# Altium schematic sheet V831.SchDoc
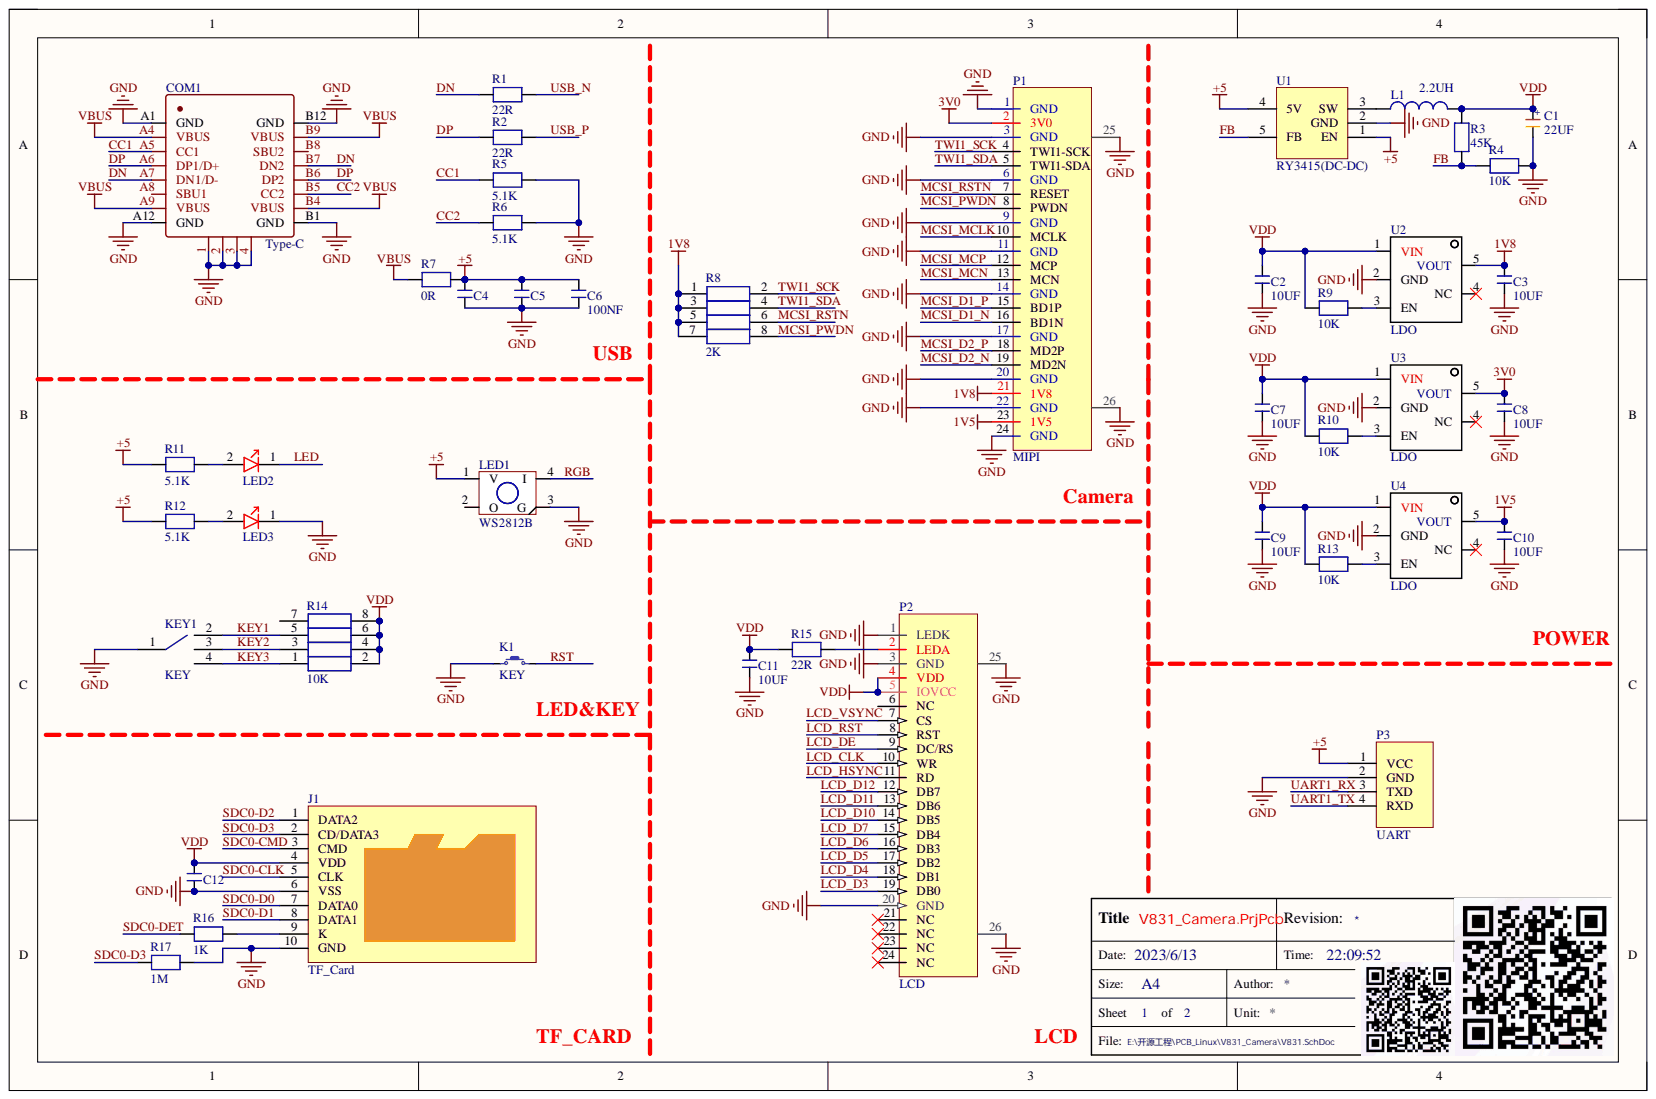
[diagram p0: V831.SchDoc]

## Parts
C1  22UF  [CAP_3216_A]
C2  10UF  [CAP_1206]
C3  10UF  [CAP_0603]
C4  100NF  [CAP_0603]  (value not drawn)
C5  100NF  [CAP_0603]  (value not drawn)
C6  100NF  [CAP_0603]
C7  10UF  [CAP_0603]
C8  10UF  [CAP_0603]
C9  10UF  [CAP_0603]
C10  10UF  [CAP_0603]
C11  10UF  [CAP_0603]
C12  100NF  [CAP_0603]  (value not drawn)
COM1  Type-C  [USB-Type-C_16A]
J1  TF_Card  [TF_Card]
K1  KEY  [KEY_SMD-4P]
KEY1  KEY  [KEY-K1-1502SA-LY-K3]
L1  2.2UH  [Inductance_nr]
LED1  WS2812B  [WS2812B]
LED2  LED_R/G/B/Y  [LED_0603_B]  (value not drawn)
LED3  LED_R/G/B/Y  [LED_0603_R]  (value not drawn)
P1  MIPI  [FPC-24Pin]
P2  LCD  [FPC-24Pin]
P3  UART  [XH2.54-WS-4P]
R1  22R  [RESC_0603_2]
R2  22R  [RESC_0603_2]
R3  45K  [RESC_0603_2]
R4  10K  [RESC_0603_2]
R5  5.1K  [RESC_0603_2]
R6  5.1K  [RESC_0603_2]
R7  0R  [RESC_0603_2]
R8  2K  [RESC_0603_4]
R9  10K  [RESC_0603_2]
R10  10K  [RESC_0603_2]
R11  5.1K  [RESC_0603_2]
R12  5.1K  [RESC_0603_2]
R13  10K  [RESC_0603_2]
R14  10K  [RESC_0603_4]
R15  22R  [RESC_0603_2]
R16  1K  [RESC_0603_2]
R17  1M  [RESC_0603_2]
U1  RY3415(DC-DC)  [SOT23_5]
U2  LDO  [SOT23_5]
U3  LDO  [SOT23_5]
U4  LDO  [SOT23_5]

## Nets
1V5: C10.2 P1.23(1V5) U4.5(VOUT)
1V8: C3.2 P1.21(1V8) R8.1 R8.3 R8.5 R8.7 U2.5(VOUT)
3V0: C8.2 P1.2(3V0) U3.5(VOUT)
+5: C4.2 C5.2 C6.2 LED1.1(V) P3.1(VCC) R7.2 R11.1 R12.1 U1.1(EN) U1.4(5V)
GND: C1.2 C2.1 C3.1 C4.1 C5.1 C6.1 C7.1 C8.1 C9.1 C10.1 C11.1 C12.1 COM1.1 COM1.2 COM1.3 COM1.4 COM1.A1(GND) COM1.A12(GND) COM1.B1(GND) COM1.B12(GND) J1.6(VSS) J1.10(GND) K1.1 KEY1.1 LED1.3(G) LED3.1 P1.1(GND) P1.3(GND) P1.6(GND) P1.9(GND) P1.11(GND) P1.14(GND) P1.17(GND) P1.20(GND) P1.22(GND) P1.24(GND) P1.25 P1.26 P2.1(LEDK) P2.3(GND) P2.20(GND) P2.25 P2.26 P3.2(GND) R4.2 R5.2 R6.2 R17.2 U1.2(GND) U2.2(GND) U3.2(GND) U4.2(GND)
VBUS: COM1.A4(VBUS) COM1.A9(VBUS) COM1.B4(VBUS) COM1.B9(VBUS) R7.1
VDD: C1.1 C2.2 C7.2 C9.2 C11.2 C12.2 J1.4(VDD) L1.2 P2.4(VDD) P2.5(IOVCC) R3.1 R9.2 R10.2 R13.2 R14.2 R14.4 R14.6 R14.8 R15.1 U2.1(VIN) U3.1(VIN) U4.1(VIN)
CC1: COM1.A5(CC1) R5.1
CC2: COM1.B5(CC2) R6.1
DN: COM1.A7(DN1/D-) COM1.B7(DN2) R1.1
DP: COM1.A6(DP1/D+) COM1.B6(DP2) R2.1
FB: R3.2 R4.1 U1.5(FB)
KEY1: KEY1.2 R14.5
KEY2: KEY1.3 R14.3
KEY3: KEY1.4 R14.1
LCD_CLK: P2.10(WR)
LCD_D3: P2.19(DB0)
LCD_D4: P2.18(DB1)
LCD_D5: P2.17(DB2)
LCD_D6: P2.16(DB3)
LCD_D7: P2.15(DB4)
LCD_D10: P2.14(DB5)
LCD_D11: P2.13(DB6)
LCD_D12: P2.12(DB7)
LCD_DE: P2.9(DC/RS)
LCD_HSYNC: P2.11(RD)
LCD_RST: P2.8(RST)
LCD_VSYNC: P2.7(CS)
LED: LED2.1
MCSI_D1_N: P1.16(BD1N)
MCSI_D1_P: P1.15(BD1P)
MCSI_D2_N: P1.19(MD2N)
MCSI_D2_P: P1.18(MD2P)
MCSI_MCLK: P1.10(MCLK)
MCSI_MCN: P1.13(MCN)
MCSI_MCP: P1.12(MCP)
MCSI_PWDN: P1.8(PWDN) R8.8
MCSI_RSTN: P1.7(RESET) R8.6
RGB: LED1.4(I)
RST: K1.2
SDC0-CLK: J1.5(CLK)
SDC0-CMD: J1.3(CMD)
SDC0-D0: J1.7(DATA0)
SDC0-D1: J1.8(DATA1)
SDC0-D2: J1.1(DATA2)
SDC0-D3: J1.2(CD/DATA3) R17.1
SDC0-DET: R16.1
TWI1_SCK: P1.4(TWI1-SCK) R8.2
TWI1_SDA: P1.5(TWI1-SDA) R8.4
UART1_RX: P3.3(TXD)
UART1_TX: P3.4(RXD)
USB_N: R1.2
USB_P: R2.2
NetJ1_9: J1.9(K) R16.2
NetL1_1: L1.1 U1.3(SW)
NetLED2_2: LED2.2 R11.2
NetLED3_2: LED3.2 R12.2
NetP2_2: P2.2(LEDA) R15.2
NetR9_1: R9.1 U2.3(EN)
NetR10_1: R10.1 U3.3(EN)
NetR13_1: R13.1 U4.3(EN)

Not connected: COM1 A8(SBU1) B8(SBU2); LED1 2(O); P2 6(NC) 21(NC) 22(NC) 23(NC) 24(NC); R14 7; U2 4(NC); U3 4(NC); U4 4(NC)

## Library data of the parts
C1 = CAP_TA: CAP  6~12V  钽电容; Component Kind: Standard; Library Name: User.SchLib
C2-C12 = CAP: CAP  12~50V; Component Kind: Standard; Library Name: User.SchLib
COM1 = USB-type_c: USB; Component Kind: Standard; Library Name: User.SchLib
J1 = TF_Card: TF卡座; Component Kind: Standard; Library Name: User.SchLib
KEY1 = LY-K3: Component Kind: Standard; Description: KEY; Library Name: User.SchLib
L1 = Inductor: Code_IEC: 1005; Code_JEITA: 0402; Component Kind: Standard; Description: Inductor; LatestRevisionDate: 29-May-2009; LatestRevisionNote: IPC-7351 Footprint Added.; Library Name: User.SchLib; PackageDescription: Chip Inductor; PackageReference: 0402-A; Published: 8-Jun-2000; Publisher: Altium Limited
LED1 = WS2812B: LED; Component Kind: Standard; Library Name: User.SchLib
LED2 LED3 = LED_SMT: LED; Component Kind: Standard; Library Name: User.SchLib
P1 = OV2465: Component Kind: Standard; Description: OV2465; Library Name: User.SchLib
P2 = LCD-ST7789-Pin24: Show 2.4-2.8寸; Component Kind: Standard; Library Name: User.SchLib
P3 = UART: Header; Component Kind: Standard; Library Name: User.SchLib
R1-R7 R9-R13 R15-R17 = RES: RES 贴片; Component Kind: Standard; Library Name: User.SchLib
R8 R14 = RES_P4: RES; Component Kind: Standard; Library Name: User.SchLib
U1 = RY3415: Component Kind: Standard; Description: RY3415(DC-DC); Library Name: User.SchLib
U2-U4 = LDO_SOT23-5: LDO  3.3V  500ma; Component Kind: Standard; Library Name: User.SchLib
K1 = KEY: Component Kind: Standard; Description: Key; Library Name: User.SchLib
